# Altium schematic sheet Gator Clips.SchDoc
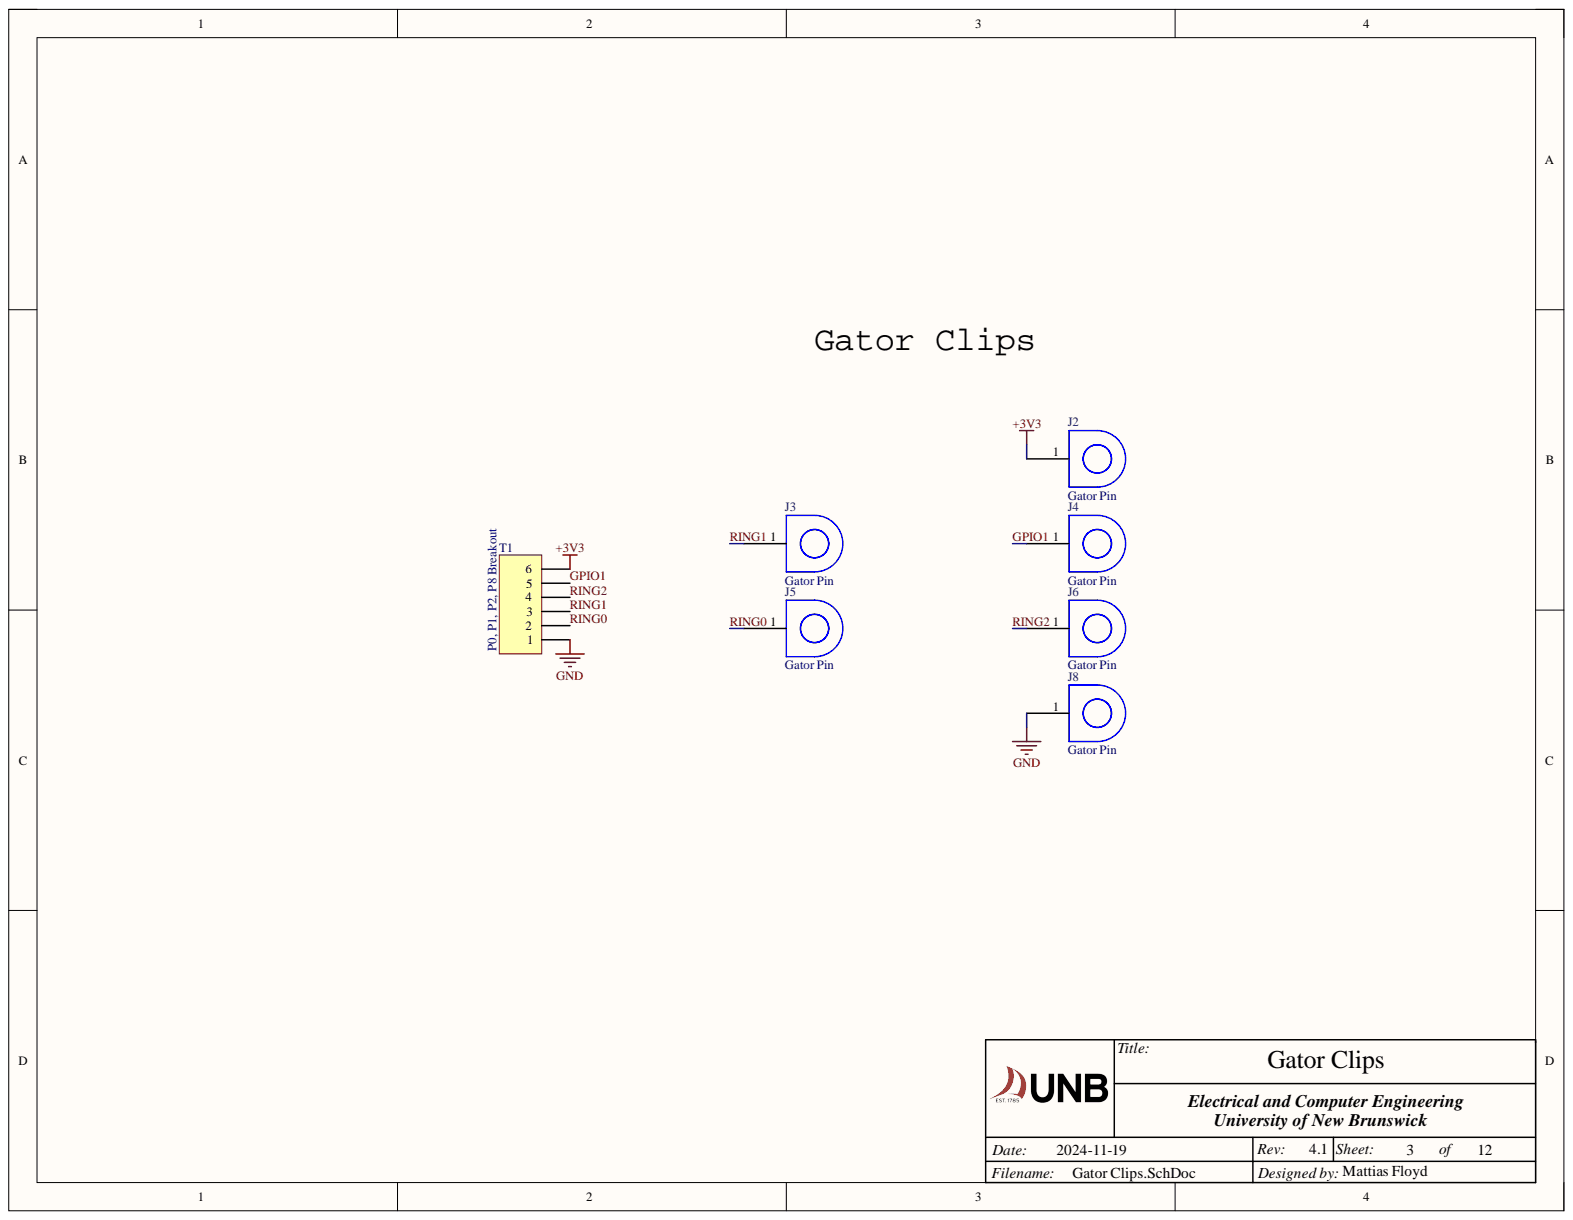
[diagram p0: Gator Clips.SchDoc]

## Parts
J2  Gator Pin  [Gator Clip Stepped]
J3  Gator Pin  [Gator Clip Stepped]
J4  Gator Pin  [Gator Clip Stepped]
J5  Gator Pin  [Gator Clip Stepped]
J6  Gator Pin  [Gator Clip Stepped]
J8  Gator Pin  [Gator Clip Stepped]
T1  P0, P1, P2, P8 Breakout  [OSTTE060104 - On Shore Tech]

## Nets
+3V3: J2.1 T1.6
GND: J8.1 T1.1
GPIO1: J4.1 T1.5
RING0: J5.1 T1.2
RING1: J3.1 T1.3
RING2: J6.1 T1.4

## Library data of the parts
J2-J6 J8 = Gator Pin: Pin for easy alligator clamping and soldering; Component Kind: Standard; Library Name: BrillianBit.SCHLIB
T1 = Header 6: TERMINAL BLOCK 3.5MM 6POS PCB; Manufacturer: On-Shore Technology; Manufacturer Part Number: OSTTE060104; Color: Black; Current: 10A; Number of Levels: 1; Number of Positions Per Level: 6; Pitch: 0.138inch; Plating: Steel; Voltage: 125V; Wire Gauge (Max): 26AWG; Wire Gauge (Min): 16AWG; Category: Terminal Blocks; Component Kind: Standard; ComponentLink1Description: http://www.on-shore.com/sites/default/files/manuals/osttexx0164_0.pdf; ComponentLink1URL: http://www.on-shore.com/sites/default/files/manuals/osttexx0164_0.pdf; ComponentLink2Description: http://www.on-shore.com/wp-content/uploads/2015/09/osttexx0164.pdf?src-supplier=Digi-Key; ComponentLink2URL: http://www.on-shore.com/wp-content/uploads/2015/09/osttexx0164.pdf?src-supplier=Digi-Key; Contact Plating: Tin; Housing Material: Thermoplastic; Library Name: Miscellaneous Connectors.IntLib; Max Operating Temperature: 105°C; Min Operating Temperature: -30°C; Mount: Through Hole; Number of Pins: 6; Packaging: Bulk; RoHS: Yes; Signal Integrity: Connector; Stock 1: 859; Supplier 1: Digi-Key; Supplier Part Number 1: ED2744-ND; Termination: Screw
